# Altium schematic sheet x-IMU3-SA-D3.SchDoc
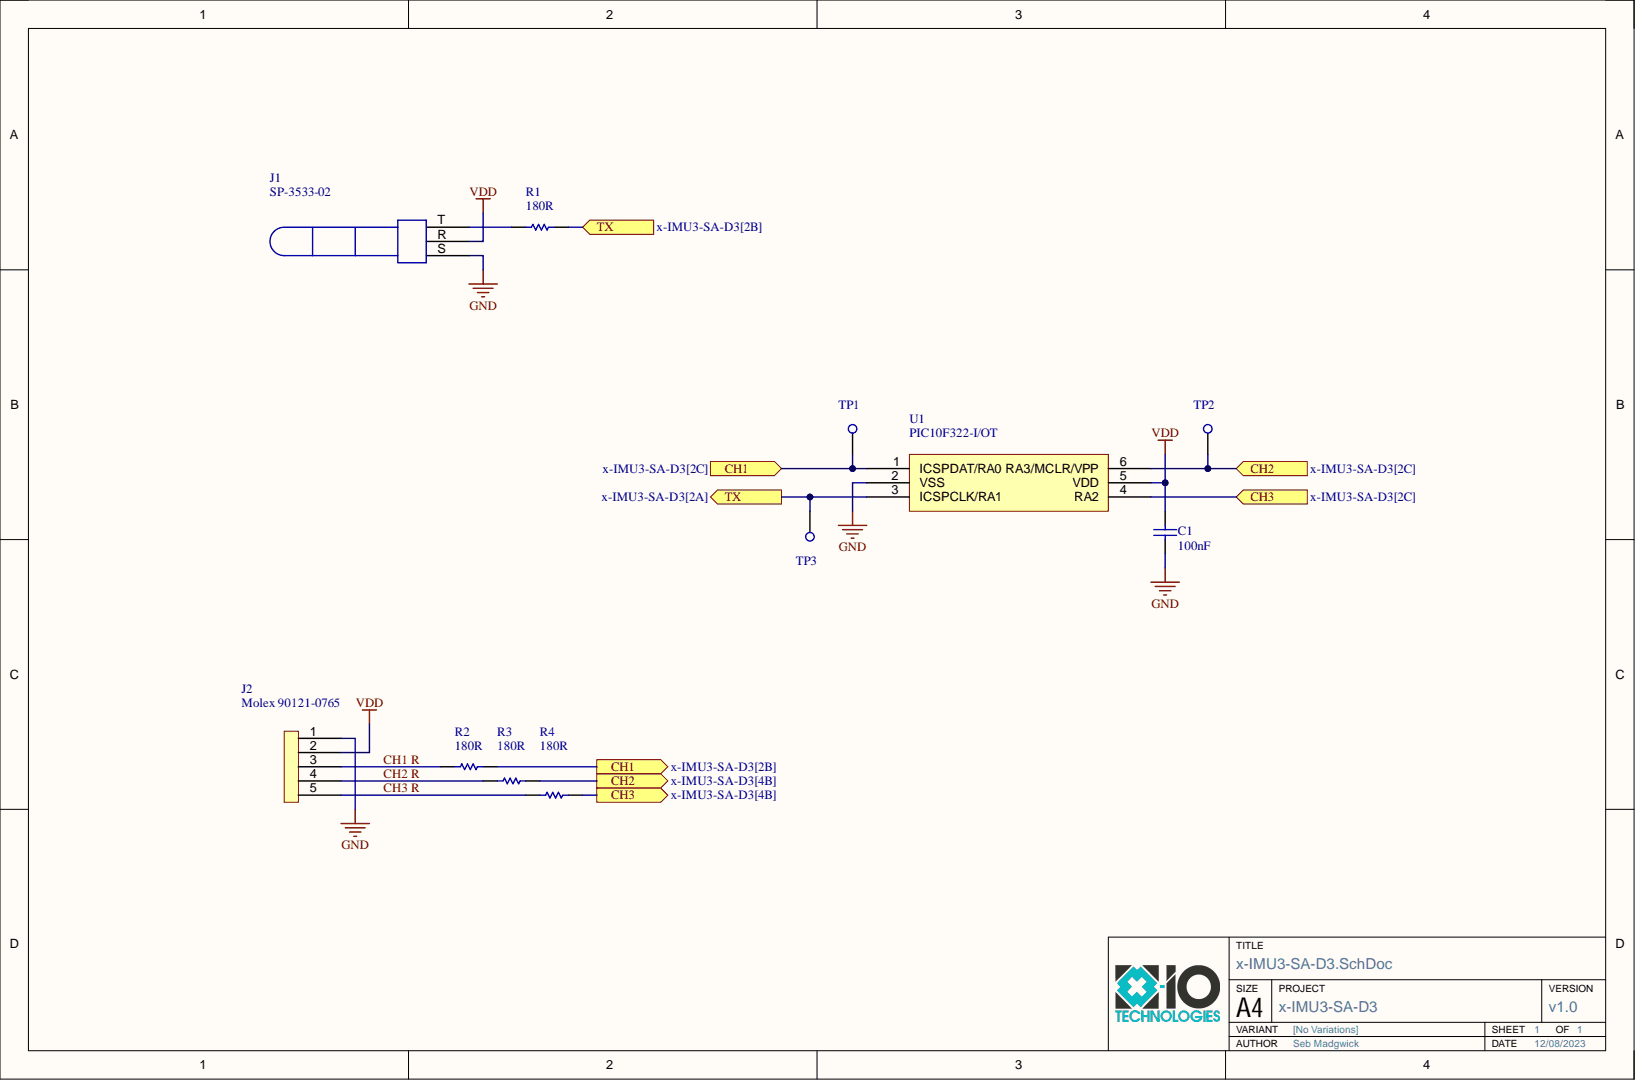
[diagram p0: x-IMU3-SA-D3.SchDoc]

## Parts
C1  100nF  [Capacitor 0402]
J1  SP-3533-02  [SP-3533-02]
J2  Molex 90121-0765  [Molex 90121-0765]
R1  180R  [Resistor 0402]
R2  180R  [Resistor 0402]
R3  180R  [Resistor 0402]
R4  180R  [Resistor 0402]
TP1  Test Point  [Test Point 1mm]  (value not drawn)
TP2  Test Point  [Test Point 1mm]  (value not drawn)
TP3  Test Point  [Test Point 1mm]  (value not drawn)
U1  PIC10F322-I/OT  [SOT-23-6]  (value not drawn)

## Ports
CH1, CH2, CH3, TX

## Nets
GND: C1.1 J1.S J2.1 U1.2(VSS)
VDD: C1.2 J1.R J2.2 U1.5(VDD)
CH1: R2.2 TP1.1 U1.1(ICSPDAT/RA0) ; port CH1
CH1 R: J2.3 R2.1
CH2: R3.2 TP2.1 U1.6(RA3/MCLR/VPP) ; port CH2
CH2 R: J2.4 R3.1
CH3: R4.2 U1.4(RA2) ; port CH3
CH3 R: J2.5 R4.1
TX: R1.2 TP3.1 U1.3(ICSPCLK/RA1) ; port TX
NetJ1_T: J1.T R1.1

## Library data of the parts
C1 = Capacitor: Component Kind: Standard; Library Name: x-io.SchLib
R1-R4 = Resistor: Component Kind: Standard; Library Name: x-io.SchLib
J1 = SP-3533-02: Component Kind: Standard; Library Name: x-io.SchLib
J2 = Molex 90121-0765: Component Kind: Standard; Library Name: x-io.SchLib
TP1-TP3 = Test Point: Component Kind: Standard; Library Name: x-io.SchLib
U1 = PIC10F322-I/OT: Component Kind: Standard; Library Name: x-io.SchLib
